# Altium schematic sheet PM3_Bosclone_ANALOG_3.SchDoc(PM3_Bosclone_ANALOG_3)
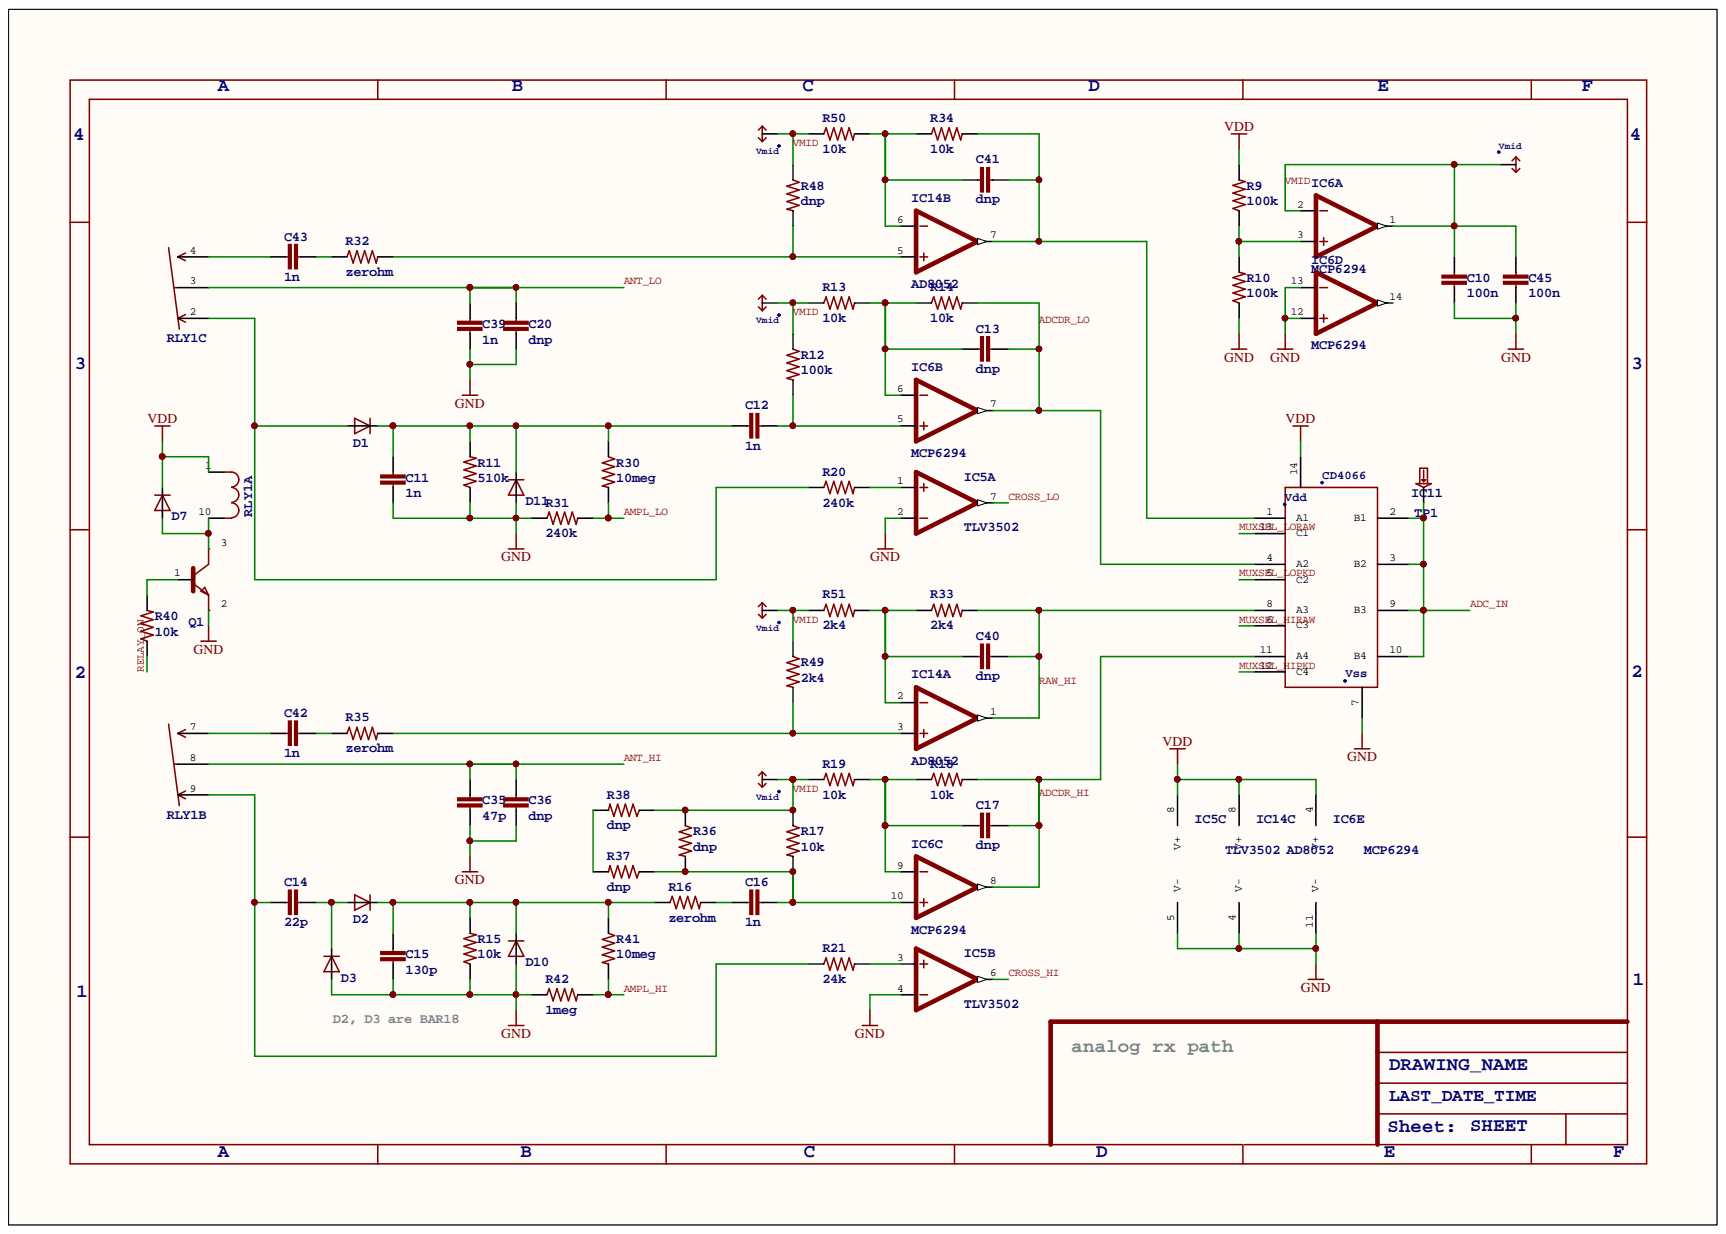
[diagram p0: PM3_Bosclone_ANALOG_3.SchDoc]

## Parts
C10  100n  [RLC_0603]
C11  1n  [RLC_0603]
C12  1n  [RLC_0603]
C13  dnp  [RLC_0603]
C14  22p  [RLC_0603]
C15  130p  [RLC_0603]
C16  1n  [RLC_0603]
C17  dnp  [RLC_0603]
C20  dnp  [RLC_0603]
C35  47p  [RLC_0603]
C36  dnp  [RLC_0603]
C39  1n  [RLC_0603]
C40  dnp  [RLC_0603]
C41  dnp  [RLC_0603]
C42  1n  [RLC_0603]
C43  1n  [RLC_0603]
C45  100n  [RLC_0603]
D1  DIODE-SMD-SOT23  [SOT23-3LEAD]  (value not drawn)
D2  DIODE-SMD-SOT23  [SOT23-3LEAD]  (value not drawn)
D3  DIODE-SMD-SOT23  [SOT23-3LEAD]  (value not drawn)
D7  DIODE-SMD-SOT23  [SOT23-3LEAD]  (value not drawn)
D10  DIODE-SMD-SOT23  [SOT23-3LEAD]  (value not drawn)
D11  DIODE-SMD-SOT23  [SOT23-3LEAD]  (value not drawn)
IC5  TLV3502  [SOT-23-8-OR-MSOP-8]  (parts A,B,C)
IC6  MCP6294  [TSSOP-14]  (parts A,B,C,D,E)
IC11  CD4066  [TSSOP-14]
IC14  AD8052  [MSOP8]  (parts A,B,C)
Q1  NPN-SOT23-2N3904  [SOT23-3LEAD]  (value not drawn)
R9  100k  [RLC_0603]
R10  100k  [RLC_0603]
R11  510k  [RLC_0603]
R12  100k  [RLC_0603]
R13  10k  [RLC_0603]
R14  10k  [RLC_0603]
R15  10k  [RLC_0603]
R16  zerohm  [RLC_0603]
R17  10k  [RLC_0603]
R18  10k  [RLC_0603]
R19  10k  [RLC_0603]
R20  240k  [RLC_0603]
R21  24k  [RLC_0603]
R30  10meg  [RLC_0603]
R31  240k  [RLC_0603]
R32  zerohm  [RLC_0603]
R33  2k4  [RLC_0603]
R34  10k  [RLC_0603]
R35  zerohm  [RLC_0603]
R36  dnp  [RLC_0603]
R37  dnp  [RLC_0603]
R38  dnp  [RLC_0603]
R40  10k  [RLC_0603]
R41  10meg  [RLC_0603]
R42  1meg  [RLC_0603]
R48  dnp  [RLC_0603]
R49  2k4  [RLC_0603]
R50  10k  [RLC_0603]
R51  2k4  [RLC_0603]
RLY1  RELAY-DPDT  [TQ-SMD-RELAY]  (value not drawn)  (parts A,B,C)
TP1  TESTPADKEYSTONE-SMD  [KEYSTONE-SMD-TESTPOINT-5015]  (value not drawn)
V13  SUPPLY_VMID  (value not drawn)
V17  SUPPLY_VMID  (value not drawn)
V21  SUPPLY_VMID  (value not drawn)
V52  SUPPLY_VMID  (value not drawn)
V53  SUPPLY_VMID  (value not drawn)

## Nets
GND: C10.2 C11.2 C15.2 C20.2 C35.2 C36.2 C39.2 C45.2 D3.1 D10.1 D11.1 IC5.2 IC5.4 IC5.5(V-) IC6.11(V-) IC6.12 IC6.13 IC11.7 IC14.4(V-) Q1.2 R10.1 R11.1 R15.1 R31.1 R42.1
VDD: D7.3 IC5.8(V+) IC6.4(V+) IC11.14 IC14.8(V+) R9.2 RLY1.1
ADC_IN: IC11.2(B1) IC11.3(B2) IC11.9(B3) IC11.10(B4) TP1.1
ADCDR_HI: C17.2 IC6.8 IC11.11(A4) R18.2
ADCDR_LO: C13.2 IC6.7 IC11.4(A2) R14.2
AMPL_HI: R41.2 R42.2
AMPL_LO: R30.2 R31.2
ANT_HI: C35.1 C36.1 RLY1.8
ANT_LO: C20.1 C39.1 RLY1.3
CROSS_HI: IC5.6
CROSS_LO: IC5.7
MUXSEL_HIPKD: IC11.12(C4)
MUXSEL_HIRAW: IC11.6(C3)
MUXSEL_LOPKD: IC11.5(C2)
MUXSEL_LORAW: IC11.13(C1)
RAW_HI: C40.2 IC11.8(A3) IC14.1 R33.2
RELAY_ON: R40.1
VMID: C10.1 C45.1 IC6.1 IC6.2 R12.1 R13.1 R17.1 R19.1 R36.1 R38.1 R48.1 R49.1 R50.1 R51.1 V13.VMID V17.VMID V21.VMID V52.VMID V53.VMID
NetC11_1: C11.1 C12.1 D1.3 D11.3 R11.2 R30.1
NetC12_2: C12.2 IC6.5 R12.2
NetC13_1: C13.1 IC6.6 R13.2 R14.1
NetC14_1: C14.1 R21.1 RLY1.9
NetC14_2: C14.2 D2.1 D3.3
NetC15_1: C15.1 D2.3 D10.3 R15.2 R16.1 R41.1
NetC16_1: C16.1 R16.2
NetC16_2: C16.2 IC6.10 R17.2 R36.2 R37.1
NetC17_1: C17.1 IC6.9 R18.1 R19.2
NetC40_1: C40.1 IC14.2 R33.1 R51.2
NetC41_1: C41.1 IC14.6 R34.1 R50.2
NetC41_2: C41.2 IC11.1(A1) IC14.7 R34.2
NetC42_1: C42.1 RLY1.7
NetC42_2: C42.2 R35.1
NetC43_1: C43.1 RLY1.4
NetC43_2: C43.2 R32.1
NetD1_1: D1.1 R20.1 RLY1.2
NetD7_1: D7.1 Q1.3 RLY1.10
NetIC5_1: IC5.1 R20.2
NetIC5_3: IC5.3 R21.2
NetIC6_3: IC6.3 R9.1 R10.2
NetIC14_3: IC14.3 R35.2 R49.2
NetIC14_5: IC14.5 R32.2 R48.2
NetQ1_1: Q1.1 R40.2
NetR37_2: R37.2 R38.2

Not connected: IC6 14

## Library data of the parts
C10-C17 C20 C35 C36 C39-C43 C45 = CAPACITOR0603: Component Kind: Standard; Library Name: merged.IntLib
IC5 = COMP-TLV3502SOT-23-8-OR-MSOP-8: Component Kind: Standard; Library Name: merged.IntLib
IC6 = OPAMP-TLC2274: Component Kind: Standard; Library Name: merged.IntLib
IC14 = OPAMP-AD8052: Component Kind: Standard; Library Name: merged.IntLib
R9-R21 R30-R38 R40-R42 R48-R51 = RESISTOR0603: Component Kind: Standard; Library Name: merged.IntLib
D1-D3 D7 D10 D11 = DIODE-SMD-SOT23: Component Kind: Standard; Library Name: merged.IntLib
IC11 = CD4066: CD4066: CD4066; Component Kind: Standard; Vdd: Vdd; Vss: Vss; Library Name: merged.IntLib
Q1 = NPN-SOT23-2N3904: Component Kind: Standard; Library Name: merged.IntLib
RLY1 = RELAY-DPDT: Component Kind: Standard; Library Name: merged.IntLib
TP1 = TESTPADKEYSTONE-SMD: Component Kind: Standard; Library Name: merged.IntLib
V13 V17 V21 V52 V53 = SUPPLY_VMID: Component Kind: Standard; Vmid: Vmid; Library Name: merged.IntLib
